# Altium schematic sheet ECU-4.SchDoc
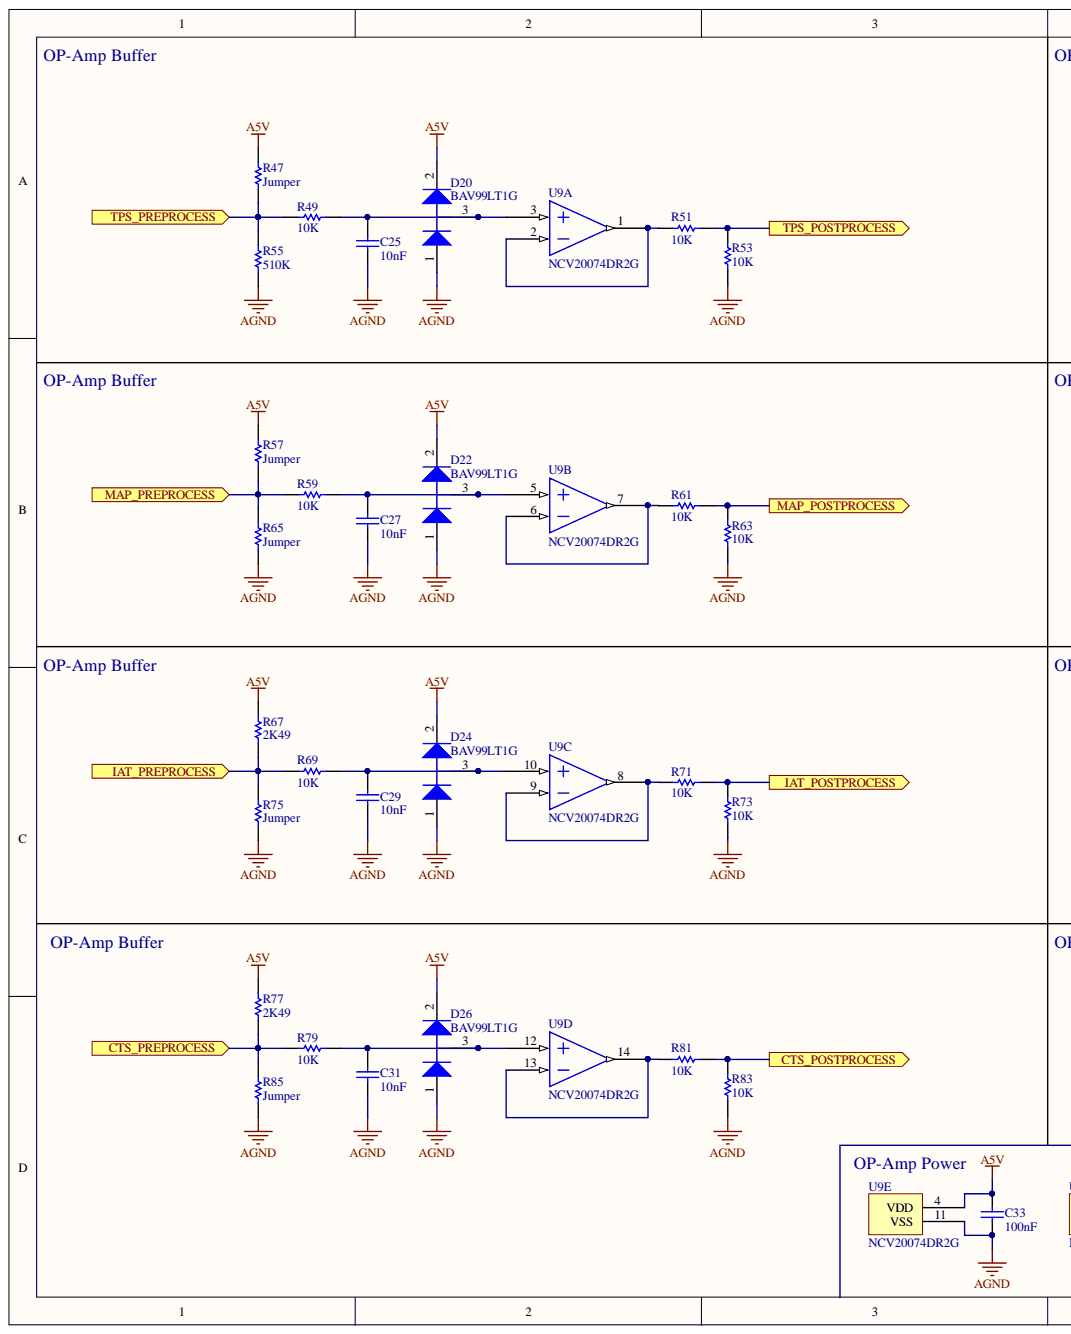
[diagram p0: ECU-4.SchDoc, left]
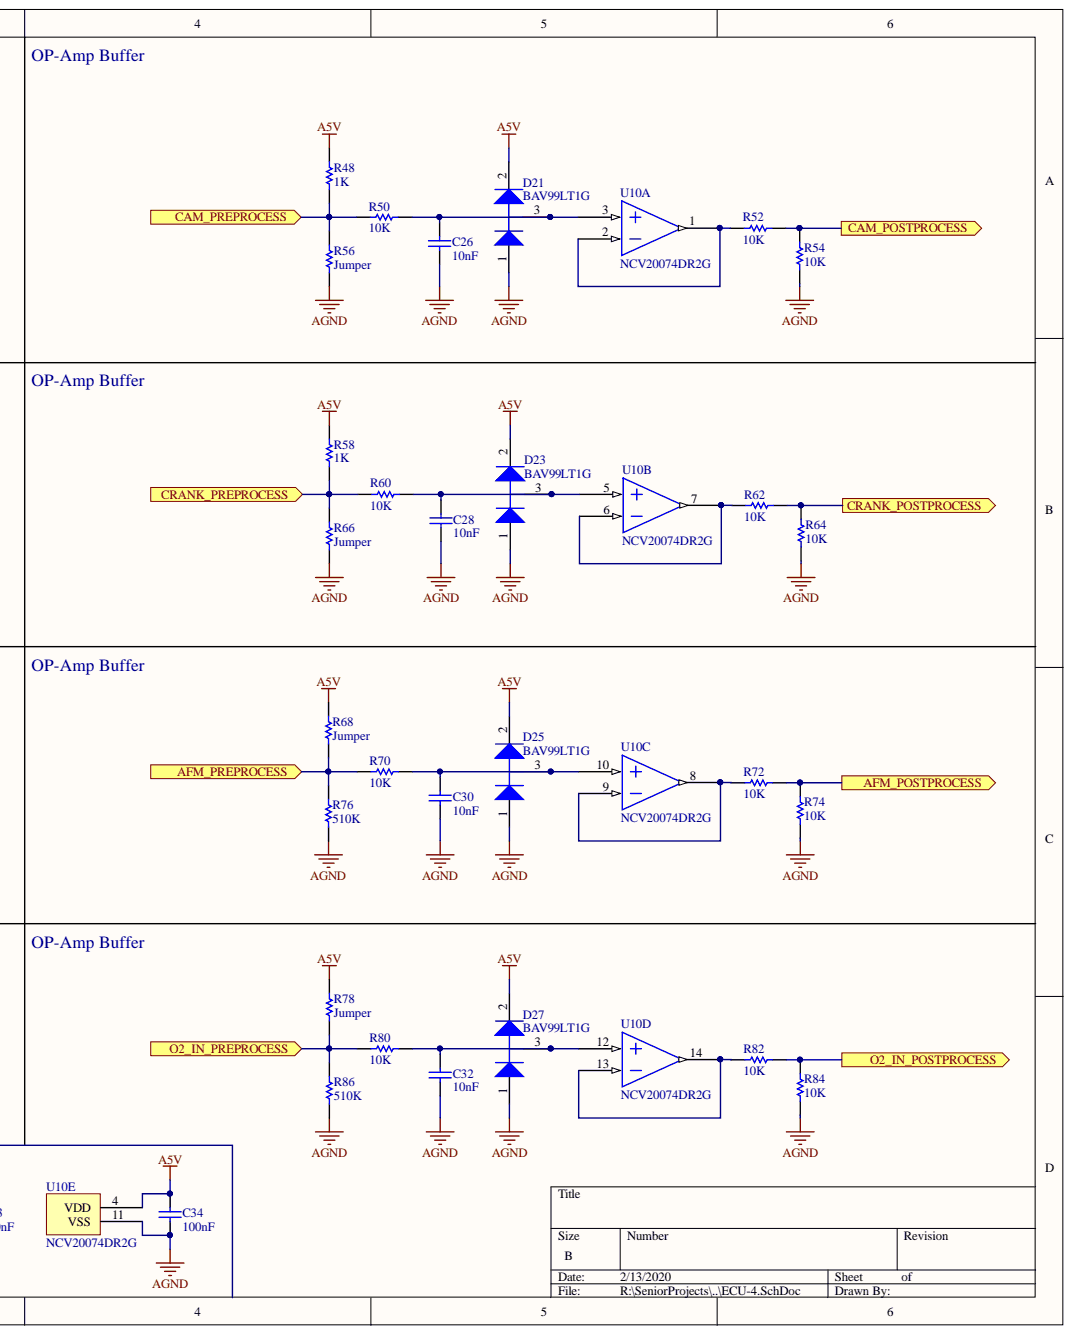
[diagram p1: ECU-4.SchDoc, right]

## Parts
C25  10nF  [CAPC0603(1608)100_L]
C26  10nF  [CAPC0603(1608)100_L]
C27  10nF  [CAPC0603(1608)100_L]
C28  10nF  [CAPC0603(1608)100_L]
C29  10nF  [CAPC0603(1608)100_L]
C30  10nF  [CAPC0603(1608)100_L]
C31  10nF  [CAPC0603(1608)100_L]
C32  10nF  [CAPC0603(1608)100_L]
C33  100nF  [CAPC0603(1608)100_M]
C34  100nF  [CAPC0603(1608)100_M]
D20  BAV99LT1G  [ONSC-SOT-23-3-318-08_V]
D21  BAV99LT1G  [ONSC-SOT-23-3-318-08_V]
D22  BAV99LT1G  [ONSC-SOT-23-3-318-08_V]
D23  BAV99LT1G  [ONSC-SOT-23-3-318-08_V]
D24  BAV99LT1G  [ONSC-SOT-23-3-318-08_V]
D25  BAV99LT1G  [ONSC-SOT-23-3-318-08_V]
D26  BAV99LT1G  [ONSC-SOT-23-3-318-08_V]
D27  BAV99LT1G  [ONSC-SOT-23-3-318-08_V]
R47  Jumper  [RESC0603(1608)_N]
R48  1K  [RESC0603(1608)_M]
R49  10K  [RESC0603(1608)_N]
R50  10K  [RESC0603(1608)_N]
R51  10K  [RESC0603(1608)_N]
R52  10K  [RESC0603(1608)_N]
R53  10K  [RESC0603(1608)_N]
R54  10K  [RESC0603(1608)_N]
R55  510K  [RESC0603(1608)_N]
R56  Jumper  [RESC0603(1608)_N]
R57  Jumper  [RESC0603(1608)_N]
R58  1K  [RESC0603(1608)_M]
R59  10K  [RESC0603(1608)_N]
R60  10K  [RESC0603(1608)_N]
R61  10K  [RESC0603(1608)_N]
R62  10K  [RESC0603(1608)_N]
R63  10K  [RESC0603(1608)_N]
R64  10K  [RESC0603(1608)_N]
R65  Jumper  [RESC0603(1608)_N]
R66  Jumper  [RESC0603(1608)_N]
R67  2K49  [RESC0603(1608)_N]
R68  Jumper  [RESC0603(1608)_N]
R69  10K  [RESC0603(1608)_N]
R70  10K  [RESC0603(1608)_N]
R71  10K  [RESC0603(1608)_N]
R72  10K  [RESC0603(1608)_N]
R73  10K  [RESC0603(1608)_N]
R74  10K  [RESC0603(1608)_N]
R75  Jumper  [RESC0603(1608)_N]
R76  510K  [RESC0603(1608)_N]
R77  2K49  [RESC0603(1608)_N]
R78  Jumper  [RESC0603(1608)_N]
R79  10K  [RESC0603(1608)_N]
R80  10K  [RESC0603(1608)_N]
R81  10K  [RESC0603(1608)_N]
R82  10K  [RESC0603(1608)_N]
R83  10K  [RESC0603(1608)_N]
R84  10K  [RESC0603(1608)_N]
R85  Jumper  [RESC0603(1608)_N]
R86  510K  [RESC0603(1608)_N]
U9  NCV20074DR2G  [NCV20074DR2G]  (parts A,B,C,D,E)
U10  NCV20074DR2G  [NCV20074DR2G]  (parts A,B,C,D,E)

## Ports
AFM_POSTPROCESS, AFM_PREPROCESS, CAM_POSTPROCESS, CAM_PREPROCESS, CRANK_POSTPROCESS, CRANK_PREPROCESS, CTS_POSTPROCESS, CTS_PREPROCESS, IAT_POSTPROCESS, IAT_PREPROCESS, MAP_POSTPROCESS, MAP_PREPROCESS, O2_IN_POSTPROCESS, O2_IN_PREPROCESS, TPS_POSTPROCESS, TPS_PREPROCESS

## Nets
A5V: C33.2 C34.2 D20.2 D21.2 D22.2 D23.2 D24.2 D25.2 D26.2 D27.2 R47.2 R48.2 R57.2 R58.2 R67.2 R68.2 R77.2 R78.2 U9.4(VDD) U10.4(VDD)
AGND: C25.1 C26.1 C27.1 C28.1 C29.1 C30.1 C31.1 C32.1 C33.1 C34.1 D20.1 D21.1 D22.1 D23.1 D24.1 D25.1 D26.1 D27.1 R53.1 R54.1 R55.1 R56.1 R63.1 R64.1 R65.1 R66.1 R73.1 R74.1 R75.1 R76.1 R83.1 R84.1 R85.1 R86.1 U9.11(VSS) U10.11(VSS)
AFM_POSTPROCESS: R72.2 R74.2 ; port AFM_POSTPROCESS
AFM_PREPROCESS: R68.1 R70.1 R76.2 ; port AFM_PREPROCESS
CAM_POSTPROCESS: R52.2 R54.2 ; port CAM_POSTPROCESS
CAM_PREPROCESS: R48.1 R50.1 R56.2 ; port CAM_PREPROCESS
CRANK_POSTPROCESS: R62.2 R64.2 ; port CRANK_POSTPROCESS
CRANK_PREPROCESS: R58.1 R60.1 R66.2 ; port CRANK_PREPROCESS
CTS_POSTPROCESS: R81.2 R83.2 ; port CTS_POSTPROCESS
CTS_PREPROCESS: R77.1 R79.1 R85.2 ; port CTS_PREPROCESS
IAT_POSTPROCESS: R71.2 R73.2 ; port IAT_POSTPROCESS
IAT_PREPROCESS: R67.1 R69.1 R75.2 ; port IAT_PREPROCESS
MAP_POSTPROCESS: R61.2 R63.2 ; port MAP_POSTPROCESS
MAP_PREPROCESS: R57.1 R59.1 R65.2 ; port MAP_PREPROCESS
O2_IN_POSTPROCESS: R82.2 R84.2 ; port O2_IN_POSTPROCESS
O2_IN_PREPROCESS: R78.1 R80.1 R86.2 ; port O2_IN_PREPROCESS
TPS_POSTPROCESS: R51.2 R53.2 ; port TPS_POSTPROCESS
TPS_PREPROCESS: R47.1 R49.1 R55.2 ; port TPS_PREPROCESS
NetC25_2: C25.2 D20.3 R49.2 U9.3
NetC26_2: C26.2 D21.3 R50.2 U10.3
NetC27_2: C27.2 D22.3 R59.2 U9.5
NetC28_2: C28.2 D23.3 R60.2 U10.5
NetC29_2: C29.2 D24.3 R69.2 U9.10
NetC30_2: C30.2 D25.3 R70.2 U10.10
NetC31_2: C31.2 D26.3 R79.2 U9.12
NetC32_2: C32.2 D27.3 R80.2 U10.12
NetR51_1: R51.1 U9.1 U9.2
NetR52_1: R52.1 U10.1 U10.2
NetR61_1: R61.1 U9.6 U9.7
NetR62_1: R62.1 U10.6 U10.7
NetR71_1: R71.1 U9.8 U9.9
NetR72_1: R72.1 U10.8 U10.9
NetR81_1: R81.1 U9.13 U9.14
NetR82_1: R82.1 U10.13 U10.14
N00187:

## Library data of the parts
C25-C32 = CMP-1035-02320-1: CAP 10nF 50V ±5% 0603 (1608 Metric) Thickness 1mm SMD; Case-EIA: 0603; Case-Metric: 1608; Tolerance: Â±5%; Component Kind: Standard; Library Name: Altium Content Vault; Max Thickness: 1 mm; Rated Voltage: 50 V; Technology: SMT; Value: 10nF
C33 C34 = CMP-1035-02580-1: CAP 100nF 10V ±10% 0603 (1608 Metric) Thickness 1mm SMD; Case-EIA: 0603; Case-Metric: 1608; Tolerance: Â±10%; Component Kind: Standard; Library Name: Altium Content Vault; Max Thickness: 1 mm; Rated Voltage: 10 V; Technology: SMT; Value: 100nF
D20-D27 = CMP-1054-00085-1: Dual Series Switching Diodes, 3-Pin SOT-23, Pb-Free, Tape and Reel; Manufacturer: On Semiconductor; IF Max (A): 0.215; trr Max (ns): 6; Component Kind: Standard; ComponentLink1Description: Manufacturer URL; ComponentLink1URL: http://www.onsemi.com/; ComponentLink2Description: Datasheet; ComponentLink2URL: http://www.onsemi.cn/pub_link/Collateral/BAV99LT1-D.PDF; ComponentLink3Description: Package Specification; ComponentLink3URL: http://www.onsemi.cn/pub_link/Collateral/318-08.PDF; Configuration: Dual Series; DatasheetVersion: Rev. 9; Library Name: Altium Content Vault; Mounting Technology: Surface Mount; PackageDescription: 3-Pin Small Outline Transistor, Body 2.92 x 1.3 mm, Pitch 0.95 mm; PackageReference: SOT-23-3-318-08; PackageVersion: Rev. AP, 11/2009; Packing: Tape and Reel; PartNumber: BAV99LT1G; RoHS: TRUE
R47 R56 R57 R65 R66 R68 R75 R78 R85 = CMP-1012-00001-1: Jumper 0603 (1608 Metric); Case-EIA: 0603; Case-Metric: 1608; Power: None; Value: 0.00 Ohm; Component Kind: Standard; Library Name: Altium Content Vault; Technology: SMT; Tolerance: None
R48 R58 = CMP-1012-00510-1: 1K 0.1W 1% 0603 (1608 Metric)  SMD; Case-EIA: 0603; Case-Metric: 1608; Value: 1000.00 Ohm; Component Kind: Standard; Library Name: Altium Content Vault; Power: 0.1 W; Technology: SMT; Tolerance: 1 %
R49-R54 R59-R64 R69-R74 R79-R84 = CMP-1012-00623-1: 10K 0.1W 1% 0603 (1608 Metric)  SMD; Case-EIA: 0603; Case-Metric: 1608; Value: 10000.00 Ohm; Component Kind: Standard; Library Name: Altium Content Vault; Power: 0.1 W; Technology: SMT; Tolerance: 1 %
R55 R76 R86 = CMP-1012-00816-1: 510K 0.1W 1% 0603 (1608 Metric)  SMD; Case-EIA: 0603; Case-Metric: 1608; Value: 510000.00 Ohm; Component Kind: Standard; Library Name: Altium Content Vault; Power: 0.1 W; Technology: SMT; Tolerance: 1 %
R67 R77 = CMP-1012-00553-1: 2K49 0.1W 1% 0603 (1608 Metric)  SMD; Case-EIA: 0603; Case-Metric: 1608; Value: 2490.00 Ohm; Component Kind: Standard; Library Name: Altium Content Vault; Power: 0.1 W; Technology: SMT; Tolerance: 1 %
U9 U10 = NCV20074DR2G: Component Kind: Standard; Description: NCV20074DR2G; Library Name: ECU.SchLib
